# Altium schematic sheet 03_Power_IO.SchDoc
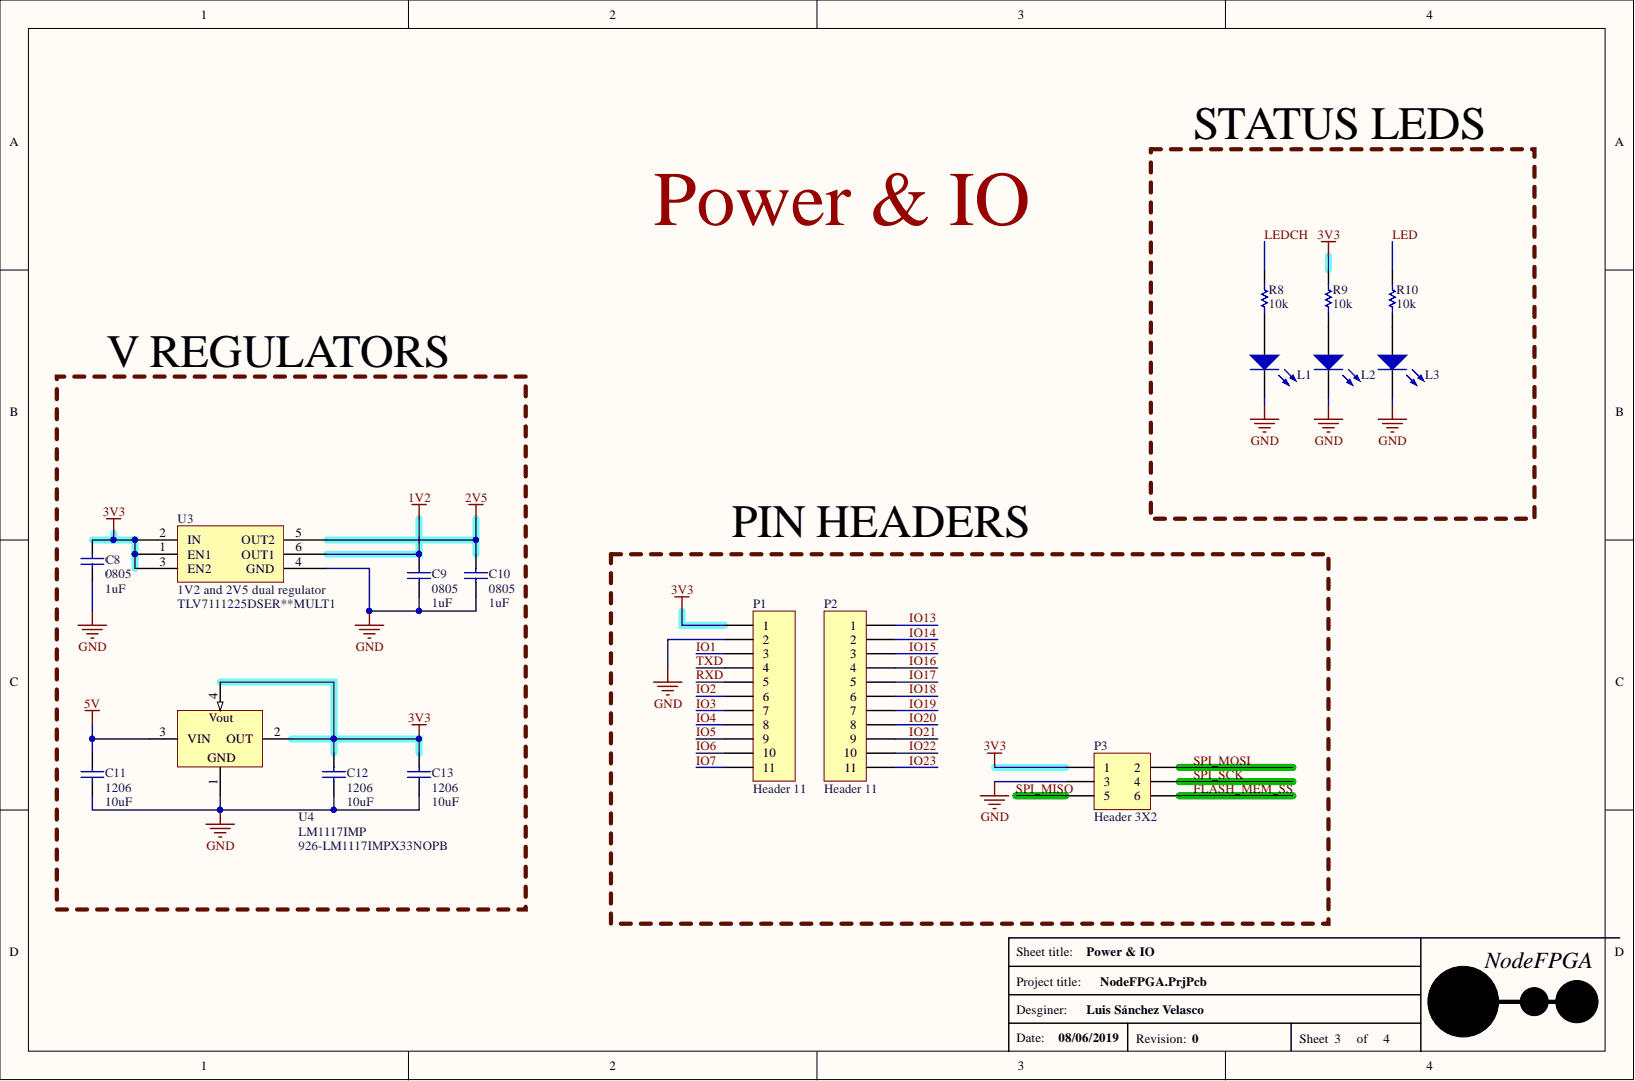
[diagram p0: 03_Power_IO.SchDoc]

## Parts
C8  0805  value 1uF  [0603 CAP]
C9  0805  value 1uF  [0603 CAP]
C10  0805  value 1uF  [0603 CAP]
C11  1206  value 10uF  [0603 CAP]
C12  1206  value 10uF  [0603 CAP]
C13  1206  value 10uF  [0603 CAP]
L1  LED_SMD  [0805]  (value not drawn)
L2  LED_SMD  [0805]  (value not drawn)
L3  LED_SMD  [0805]  (value not drawn)
P1  Header 11  [HDR1X11]
P2  Header 11  [HDR1X11]
P3  Header 3X2  [HDR2X3]
R8  value 10k  [Resistencia 0603]  (hidden comment: Resistor)
R9  value 10k  [Resistencia 0603]  (hidden comment: Resistor)
R10  value 10k  [Resistencia 0603]  (hidden comment: Resistor)
U3  1V2 and 2V5 dual regulator  Supplier Part Number 1: TLV7111225DSER**MULT1  [DSE 6]
U4  LM1117IMP  [SOT-223]

## Nets
1V2: C9.1 U3.6(OUT1)
2V5: C10.1 U3.5(OUT2)
3V3: C8.1 C12.1 C13.1 P1.1 P3.1 R9.2 U3.1(EN1) U3.2(IN) U3.3(EN2) U4.2(OUT) U4.4
5V: C11.1 U4.3(VIN)
GND: C8.2 C9.2 C10.2 C11.2 C12.2 C13.2 L1.2 L2.2 L3.2 P1.2 P3.3 U3.4(GND) U4.1
FLASH_MEM_SS: P3.6
IO1: P1.3
IO2: P1.6
IO3: P1.7
IO4: P1.8
IO5: P1.9
IO6: P1.10
IO7: P1.11
IO13: P2.1
IO14: P2.2
IO15: P2.3
IO16: P2.4
IO17: P2.5
IO18: P2.6
IO19: P2.7
IO20: P2.8
IO21: P2.9
IO22: P2.10
IO23: P2.11
LED: R10.2
LEDCH: R8.2
RXD: P1.5
SPI_MISO: P3.5
SPI_MOSI: P3.2
SPI_SCK: P3.4
TXD: P1.4
NetL1_1: L1.1 R8.1
NetL2_1: L2.1 R9.1
NetL3_1: L3.1 R10.1

## Library data of the parts
C8-C10 = Capacitor_0805: Capacitor; Component Kind: Standard; Library Name: NodeFPGA.SCHLIB; Simulation: CAP; Supplier 1: Mouser; Supplier Part Number 1: 80-C1206C104K5R
C11-C13 = Capacitor_1206: Capacitor; Component Kind: Standard; Library Name: NodeFPGA.SCHLIB; Simulation: CAP; Supplier 1: Mouser; Supplier Part Number 1: 80-C1206C104K5R
L1-L3 = LED_SMD: LED SMD; Component Kind: Standard; Supplier 1: Mouser; Supplier Part Number 1: 630-HSMC-C170; Library Name: GSATFPGA.SCHLIB
P1 P2 = Header 11_1: Header, 11-Pin; Component Kind: Standard; LatestRevisionDate: 17-Jul-2002; LatestRevisionNote: Re-released for DXP Platform.; Library Name: NodeFPGA.SCHLIB; Publisher: Altium Limited
P3 = Header 3X2: Header, 3-Pin, Dual row; Component Kind: Standard; LatestRevisionDate: 17-Jul-2002; LatestRevisionNote: Re-released for DXP Platform.; Library Name: Miscellaneous Connectors.IntLib; Publisher: Altium Limited
U3 = TLV7111225DSER: Component Kind: Standard; Supplier 1: Arrow; Library Name: GSATFPGA.SCHLIB
U4 = LM1117IMP: 5V to 3.3V 800mA Low-Dropout Linear Regulator; Component Kind: Standard; Supplier 1: Mouser; Supplier Part Number 1: 926-LM1117IMPX33NOPB; Library Name: GSATFPGA.SCHLIB
R8-R10 = Resistor: Component Kind: Standard; Description: Resistor; Library Name: OpenFPGA.SCHLIB; Simulation: RESISTOR; Supplier 1: Farnell; Supplier Part Number 1: 2358436
